# Altium schematic sheet NEAR.SchDoc
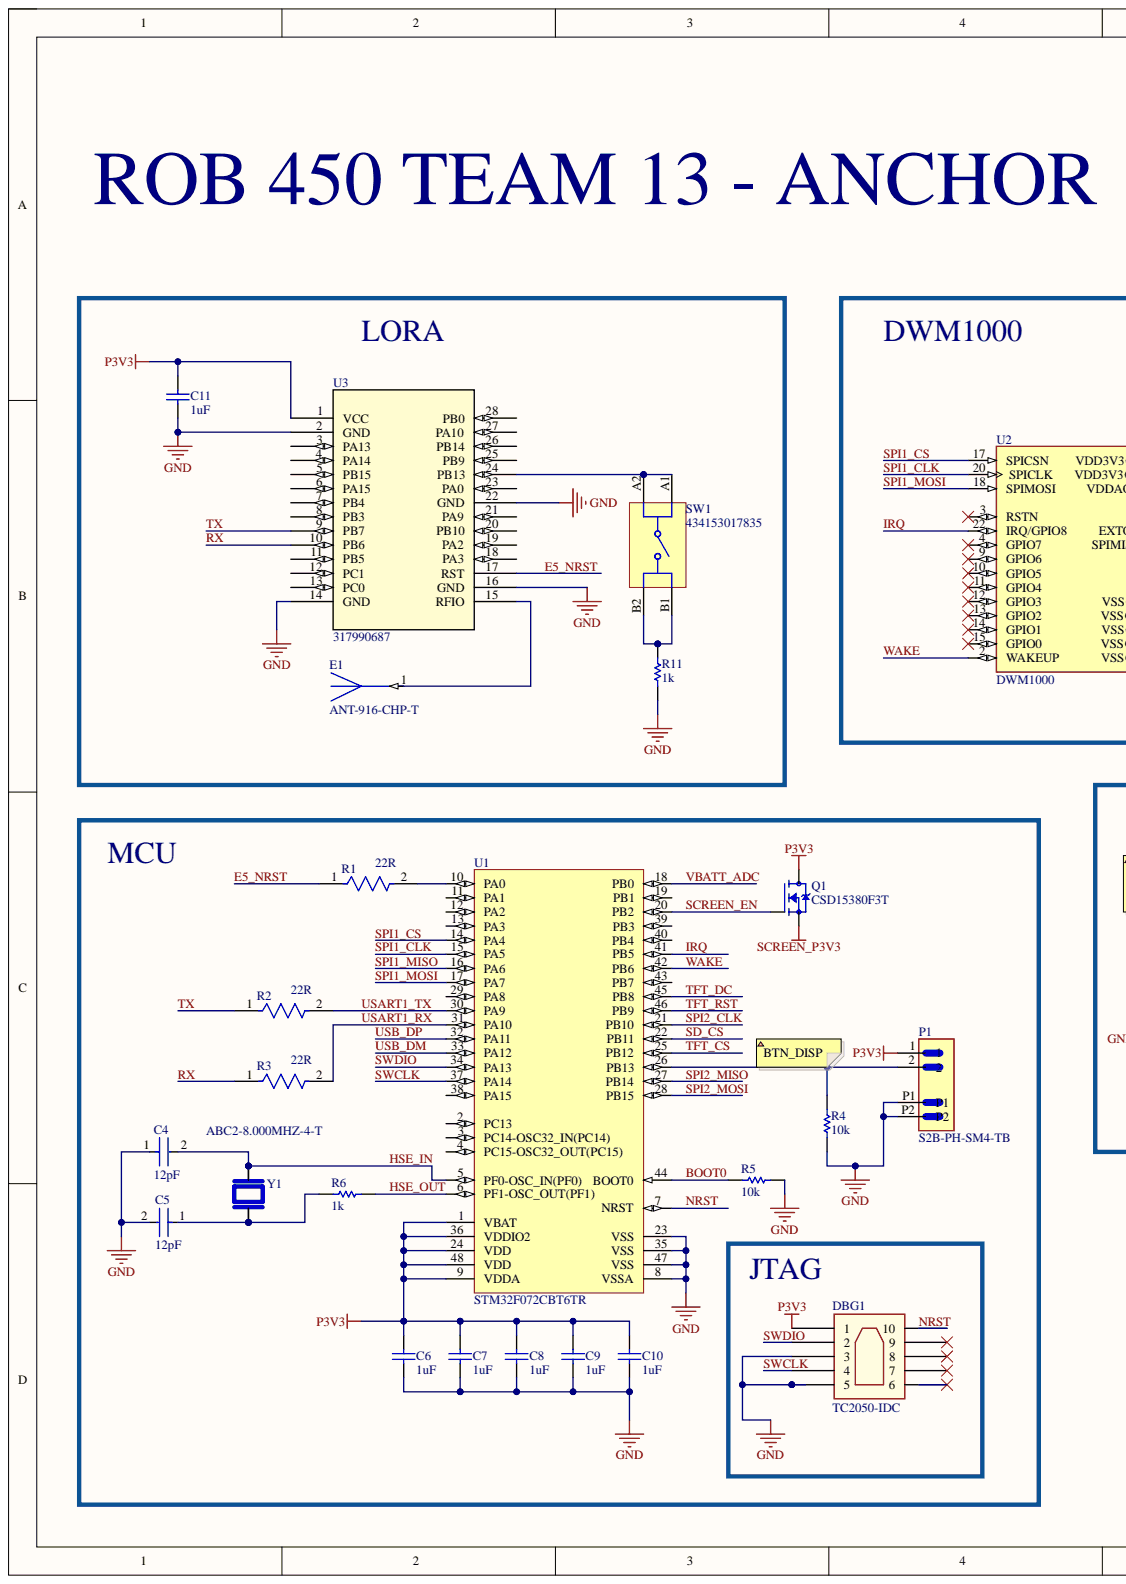
[diagram p0: NEAR.SchDoc, left]
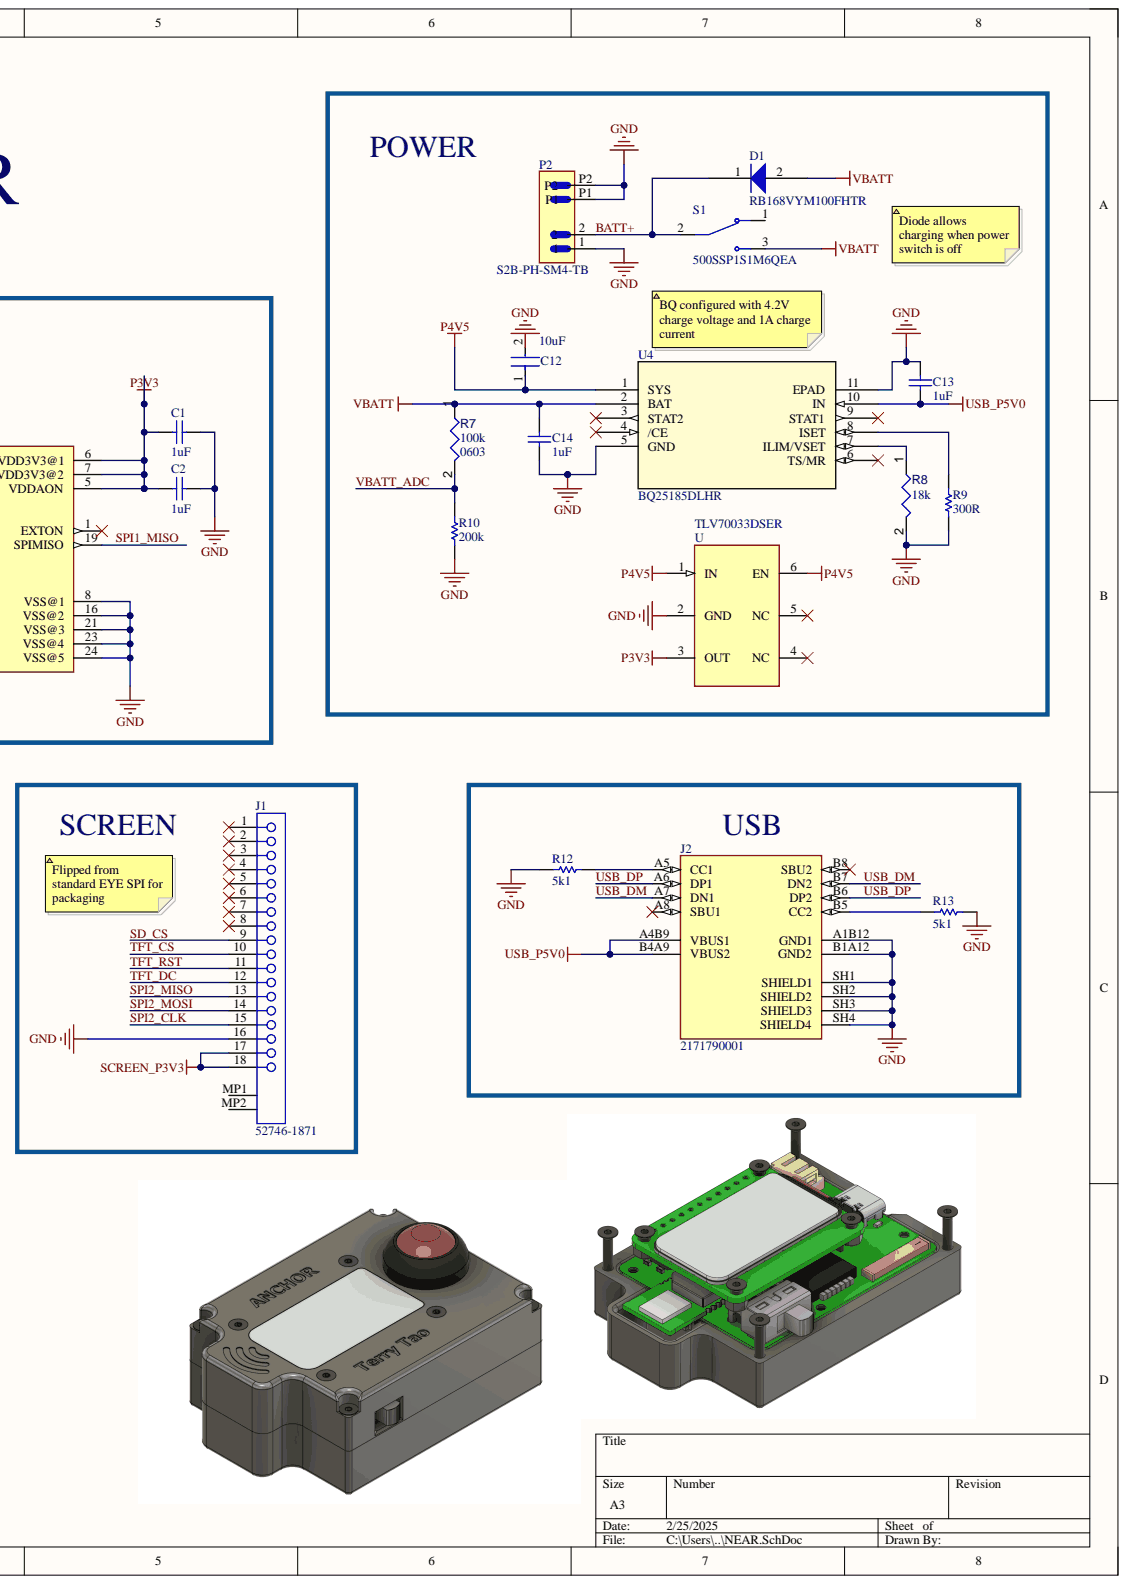
[diagram p1: NEAR.SchDoc, right]

## Parts
C1  1uF  [CAP_0603]
C2  1uF  [CAP_0603]
C4  12pF  [FP-C0603C-CF-MFG]
C5  12pF  [FP-C0603C-CF-MFG]
C6  1uF  [CAP_0603]
C7  1uF  [CAP_0603]
C8  1uF  [CAP_0603]
C9  1uF  [CAP_0603]
C10  1uF  [CAP_0603]
C11  1uF  [CAP_0603]
C12  10uF  [FP-GRM188-0_1-e0_2_0_55-IPC_A]
C13  1uF  [CAP_0603]
C14  1uF  [CAP_0603]
D1  RB168VYM100FHTR  [RB168VYM100FHTR-Footprint-1]
DBG1  TC2050-IDC  [TC2050-IDC-Footprint-2]
E1  ANT-916-CHP-T  [XDCR_ANT-916-CHP-T]
J1  52746-1871  [MOLX-52746-1871]
J2  2171790001  [MOLEX_2171790001]
P1  S2B-PH-SM4-TB  [JST_S2B-PH-SM4-TB]
P2  S2B-PH-SM4-TB  [JST_S2B-PH-SM4-TB]
Q1  CSD15380F3T  [TRXDFN69X60X35-3N]
R1  22R  [FP-CPF0603-MFG]
R2  22R  [FP-CPF0603-MFG]
R3  22R  [FP-CPF0603-MFG]
R4  10k  [RESC1609X55X30LL15T15]
R5  10k  [RESC1609X55X30LL15T15]
R6  1k  [RESC1608X55X30LL15T15]
R7  100k  Case/Package: 0603  [RESC1608X55X25ML10T15]
R8  value 18k  [CAPC1608X06N]  (hidden comment: RC0603JR-0718KL)
R9  300R  [RESC1608X55X30ML15T15]
R10  200k  [RESC1608X55X25LL10T15]
R11  1k  [RESC1608X55X30LL15T15]
R12  5k1  [RESC1608X55X25ML10T15]
R13  5k1  [RESC1608X55X25ML10T15]
S1  500SSP1S1M6QEA  [SW_500SSP1S1M6QEA]
SW1  434153017835  [434153017835]
U  TLV70033DSER  [SON50P150X150X80-6N]
U1  STM32F072CBT6TR  [STM-LQFP48_M]
U2  DWM1000  [XCVR_DWM1000]
U3  317990687  [QFN_90687_SEE]
U4  BQ25185DLHR  [WSON10_DLH_TEX]
Y1  ABC2-8.000MHZ-4-T  [XTAL_ABC2-8.000MHZ-4-T]

## Nets
GND: C1.2 C2.2 C4.1 C5.2 C6.1 C7.1 C8.1 C9.1 C10.1 C11.1 C12.2 C13.2 C14.1 DBG1.3 DBG1.5 J1.16 J2.A1B12(GND1) J2.B1A12(GND2) J2.SH1(SHIELD1) J2.SH2(SHIELD2) J2.SH3(SHIELD3) J2.SH4(SHIELD4) P1.P1 P1.P2 P2.1 P2.P1 P2.P2 R4.1 R5.1 R8.2 R9.2 R10.2 R11.2 R12.2 R13.1 U.2(GND) U1.8(VSSA) U1.23(VSS) U1.35(VSS) U1.47(VSS) U2.8(VSS@1) U2.16(VSS@2) U2.21(VSS@3) U2.23(VSS@4) U2.24(VSS@5) U3.2(GND) U3.14(GND) U3.16(GND) U3.22(GND) U4.5(GND) U4.11(EPAD)
P3V3: C1.1 C2.1 C6.2 C7.2 C8.2 C9.2 C10.2 C11.2 DBG1.1 P1.1 Q1.3 U.3(OUT) U1.1(VBAT) U1.9(VDDA) U1.24(VDD) U1.36(VDDIO2) U1.48(VDD) U2.5(VDDAON) U2.6(VDD3V3@1) U2.7(VDD3V3@2) U3.1(VCC)
P4V5: C12.1 U.1(IN) U.6(EN) U4.1(SYS)
SCREEN_P3V3: J1.17 J1.18 Q1.2
USB_P5V0: C13.1 J2.A4B9(VBUS1) J2.B4A9(VBUS2) U4.10(IN)
VBATT: C14.2 D1.2 R7.1 S1.3 U4.2(BAT)
BATT+: D1.1 P2.2 S1.2
BOOT0: R5.2 U1.44(BOOT0)
E5_NRST: R1.1 U3.17(RST)
HSE_IN: C4.2 U1.5(PF0-OSC_IN(PF0)) Y1.2 Y1.3
HSE_OUT: R6.1 U1.6(PF1-OSC_OUT(PF1))
IRQ: U1.41(PB5) U2.22(IRQ/GPIO8)
NRST: DBG1.10 U1.7(NRST)
RX: R3.1 U3.10(PB6)
SCREEN_EN: Q1.1 U1.20(PB2)
SD_CS: J1.9 U1.22(PB11)
SPI1_CLK: U1.15(PA5) U2.20(SPICLK)
SPI1_CS: U1.14(PA4) U2.17(SPICSN)
SPI1_MISO: U1.16(PA6) U2.19(SPIMISO)
SPI1_MOSI: U1.17(PA7) U2.18(SPIMOSI)
SPI2_CLK: J1.15 U1.21(PB10)
SPI2_MISO: J1.13 U1.27(PB14)
SPI2_MOSI: J1.14 U1.28(PB15)
SWCLK: DBG1.4 U1.37(PA14)
SWDIO: DBG1.2 U1.34(PA13)
TFT_CS: J1.10 U1.25(PB12)
TFT_DC: J1.12 U1.45(PB8)
TFT_RST: J1.11 U1.46(PB9)
TX: R2.1 U3.9(PB7)
USART1_RX: R3.2 U1.31(PA10)
USART1_TX: R2.2 U1.30(PA9)
USB_DM: J2.A7(DN1) J2.B7(DN2) U1.33(PA12)
USB_DP: J2.A6(DP1) J2.B6(DP2) U1.32(PA11)
VBATT_ADC: R7.2 R10.1 U1.18(PB0)
WAKE: U1.42(PB6) U2.2(WAKEUP)
NetC5_1: C5.1 R6.2 Y1.1 Y1.4
NetE1_1: E1.1 U3.15(RFIO)
NetJ2_A5: J2.A5(CC1) R12.1
NetJ2_B5: J2.B5(CC2) R13.2
NetP1_2: P1.2 R4.2 U1.26(PB13)
NetR1_2: R1.2 U1.10(PA0)
NetR8_1: R8.1 U4.7(ILIM/VSET)
NetR9_1: R9.1 U4.8(ISET)
NetR11_1: R11.1 SW1.B1 SW1.B2
NetSW1_A1: SW1.A1 SW1.A2 U3.24(PB13)

Not connected: DBG1 6 7 8 9; J1 1 2 3 4 5 6 7 8 MP1 MP2; J2 A8(SBU1) B8(SBU2); S1 1; U 4(NC) 5(NC); U1 2(PC13) 3(PC14-OSC32_IN(PC14)) 4(PC15-OSC32_OUT(PC15)) 11(PA1) 12(PA2) 13(PA3) 19(PB1) 29(PA8) 38(PA15) 39(PB3) 40(PB4) 43(PB7); U2 1(EXTON) 3(RSTN) 4(GPIO7) 9(GPIO6) 10(GPIO5) 11(GPIO4) 12(GPIO3) 13(GPIO2) 14(GPIO1) 15(GPIO0); U3 3(PA13) 4(PA14) 5(PB15) 6(PA15) 7(PB4) 8(PB3) 11(PB5) 12(PC1) 13(PC0) 18(PA3) 19(PA2) 20(PB10) 21(PA9) 23(PA0) 25(PB9) 26(PB14) 27(PA10) 28(PB0); U4 3(STAT2) 4(/CE) 6(TS/MR) 9(STAT1)

## Library data of the parts
C1 C2 C6-C11 C13 C14 = CMP-001-00097-1: Cap Ceramic 1uF 25V X7R 10% Pad SMD 0603 125C Automotive T/R; Capacitance: 1uF; Case/Package: 0603; Component Kind: Standard; Dielectric: X7R; Tolerance: 10%; Voltage Rating: 25V; Library Name: MRacing FSAE
C4 C5 = CMP-2006-03703-3: Ceramic Capacitor 12Pf 50V, C0G, 5%, 0603, Full Reel; Manufacturer: KEMET; Manufacturer Part Number: C0603C120J5GACTU; Automotive Grade: Grade 1; Temperature Coefficient: 30ppm/°C; Tolerance: 0.05; Automotive: Yes; Capacitance: 12 pF; Category: Cap; Component Kind: Standard; ComponentLink2Description: Datasheet; ComponentLink2URL: https://api.kemet.com/component-edge/download/datasheet/C0603C100J5GACAUTO.pdf; Device Class L1: Passive Components; Device Class L2: Capacitors; Device Class L3: Ceramic Capacitors; Digikey Description: Ceramic Capacitor 12Pf 50V, C0G, 5%, 0603, Full Reel; Height: 0.87mm; Lead Free: Yes; Library Name: Altium Content Vault; Material: Ceramic; Mouser Description: Ceramic Capacitor 12Pf 50V, C0G, 5%, 0603, Full Reel; Octopart: https://octopart.com/c0603c120j5gactu-kemet-108780; Package: C0603C-CF; RoHS: TRUE; Temperature: -55°C to +125°C; Temperature Characteristic: C0G; Temperature Range High: +125°C; Temperature Range Low: -55°C; Value: 12 pF; Voltage Rating: 50 V
C12 = CMP-2006-02792-2: Chip Multilayer Ceramic Capacitors for General Purpose, 0603, 10uF, X5R, 15%, 20%, 6.3V; Capacitance: 10uF; Case Code (Imperial): 0603; Case Code (Metric): 1608; Case/Package: 0603; Component Kind: Standard; Depth: 0.8mm; Dielectric: X5R; Height: 0.8mm; Lead Free: Lead Free; Length: 1.6mm; Library Name: Altium Content Vault; Material: Ceramic; Max Operating Temperature: 85°C; Min Operating Temperature: -55°C; Mount: Surface Mount; Package Quantity: 4000; Packaging: Tape and Reel; Radiation Hardening: No; REACH SVHC: No SVHC; Termination: SMD/SMT; Thickness: 0.035inch; Tolerance: 20%; Voltage: 6.3V; Voltage Rating: 6.3V; Voltage Rating (DC): 6.3V; Width: 0.031inch
D1 = CMP-004-00046-4: Schottky Barrier Diode; Component Kind: Standard; Design Item ID: RB168VYM100FHTR; Source: RB168VYM100FHTR.SchLib; Library Name: MRacing FSAE
DBG1 = CMP-002-00071-4: CBL PLUG-OF-NAILS 10-PIN W/LEGS; Component Kind: Standard; Library Name: MRacing FSAE
E1 = CMP-00025-00008-1: 915 MHz Monopole Ceramic Chip Antenna; MF: Linx Technologies; Availability: In Stock; Case/Package: None; Check_prices: https://www.snapeda.com/parts/ANT-916-CHP-T/Linx/view-part/168085/?ref=eda; Component Kind: Standard; Component Type: Standard; Design Item ID: ANT-916-CHP-T; MP: ANT-916-CHP-T; Pins: 1; Price: None; SnapEDA_Link: https://www.snapeda.com/parts/ANT-916-CHP-T/Linx/view-part/168085/?ref=snap; Source: ANT-916-CHP-T.SchLib; Library Name: MRacing FSAE
J1 = CMP-1241-00380-1: 0.5 mm Pitch Easy-On(TM) Type FPC Connector, 2.0 mm Mated Height, Right Angle, ZIF, SMT, Bottom Contact, 18 Circuits, -20 to 85 degC, ELV and RoHS Compliant, Tape and Reel; Manufacturer: Molex; Circuits (Loaded): 18; Current (Max per Contact): 0.5A; Durability (mating cycles max): 20; Entry Angle: 90degrees Angle; Mated Height: 2.00mm; Material - Metal: Phosphor Bronze; Material - Plating Mating: Gold; PCB Locator: No; PCB Retention: Yes; Pitch - Mating Interface: 0.50mm; Voltage (Max): 50V; Component Kind: Standard; ComponentLink1Description: Manufacturer URL; ComponentLink1URL: http://www.molex.com/molex/index.jsp; ComponentLink2Description: Datasheet; ComponentLink2URL: http://www.molex.com/webdocs/datasheets/pdf/en-us/0527461871_FFC_FPC_CONNECTORS.pdf; ComponentLink3Description: Package Specification; ComponentLink3URL: http://www.molex.com/pdm_docs/sd/527461871_sd.pdf; Contact Position: Bottom; Library Name: Altium Content Vault; Material - Plating Termination: Gold; Mounting Technology: Surface Mount; Number of Rows: 1; Orientation: Right Angle; PackageDescription: 18-Lead FPC Connector, Pitch 0.5 mm; PackageReference: 52746-1871; PackageVersion: Rev. H, 05/2009; Packing: Tape and Reel; PartNumber: 52746-1871; Polarized to PCB: Yes; RoHS: TRUE; Stackable: No
J2 = CMP-002-00656-1: USB Type C - Connector, Top-mount SMT, 16pos; MF: Molex; MP: 217179-0001; Case/Package: None; Check_prices: https://www.snapeda.com/parts/217179-0001/Molex/view-part/?ref=eda; Component Kind: Standard; Design Item ID: 2171790001; SnapEDA_Link: https://www.snapeda.com/parts/217179-0001/Molex/view-part/?ref=snap; Source: 2171790001.SchLib; Library Name: MRacing FSAE
P1 P2 = CMP-002-00662-3: Connector Header Surface Mount, Right Angle 2 position 0.079 (2.00mm); MF: JST Sales; Availability: In Stock; Case/Package: None; Check_prices: https://www.snapeda.com/parts/S2B-PH-SM4-TB/JST+Sales+America+Inc./view-part/?ref=eda; Component Kind: Standard; Component Type: Standard; Design Item ID: S2B-PH-SM4-TB; MP: S2B-PH-SM4-TB; Pins: 2; Price: None; Purchase-URL: https://pricing.snapeda.com/search/part/S2B-PH-SM4-TB/?ref=eda; SnapEDA_Link: https://www.snapeda.com/parts/S2B-PH-SM4-TB/JST+Sales+America+Inc./view-part/?ref=snap; Source: S2B-PH-SM4-TB.SchLib; Library Name: MRacing FSAE
Q1 = CMP-013-00048-1: 20-V N-Channel FemtoFET MOSFET 3-PICOSTAR -55 to 150; Availability: Unavailable; Case/Package: None; Component Kind: Standard; Component Type: Standard; Design Item ID: CSD15380F3T; MP: CSD15380F3T; Pins: 3; Price: None; Source: CSD15380F3T.SchLib; Library Name: MRacing FSAE
R1-R3 = CMP-03211-007811-1: RES SMD 22Ω 0.1% 1/16W 0603; Manufacturer: TE Connectivity; Manufacturer Part Number: CPF0603B22RE1; Power Rating: 0.063W; Temperature Coefficient: 25ppm/°C; Voltage Rating: 50V; Automotive: No; Category: Res; Component Kind: Standard; ComponentLink2Description: Datasheet; ComponentLink2URL: https://www.te.com/commerce/DocumentDelivery/DDEController?Action=showdoc&DocId=Data+Sheet%7F1773200%7FM%7Fpdf%7FEnglish%7FENG_DS_1773200_M.pdf%7F3-1676481-2; Composition: Thin Film; Device Class L1: Passive Components; Device Class L2: Resistors; Device Class L3: Chip SMD Resistors; Height: 0.55mm; Ipc Land Pattern Name: RESC15580X45; Lead Free: Yes; Library Name: Altium Content Vault; Octopart: https://octopart.com/cpf0603b22re1-te+connectivity-9539398; octopart_url: https://octopart.com/cpf0603b22re1-te+connectivity-9539398; Package: CPF0603; packaging: 1k Reel; Resistance: 22Ω; RoHS: TRUE; Series: CPF; Temperature: -55°C to +155°C; Temperature Range High: +155°C; Temperature Range Low: -55°C; Tolerance: 0.1%; Value: 22Ω
R4 R5 = CMP-1012-00623-3: Chip Resistor, 10 KOhm, +/- 1%, 0.1 W, -55 to 155 degC, 0603 (1608 Metric), RoHS, Tape and Reel; Manufacturer: Panasonic; Case\/Package: 0603; Max Power Dissipation: 100mW; Resistance: 10KOhm; Temperature Coefficient: 100ppm\/degreesC; Voltage Rating: 75V; Case Code (Imperial): 0603; Case Code (Metric): 1608; Component Kind: Standard; Composition: ThickFilm; Contact Plating: Tin; Datasheet URL: https://industrial.panasonic.com/cdbs/www-data/pdf/RDA0000/AOA0000C304.pdf; Datasheet Version: 02/2017; Depth: 0.8mm; Height: 0.45mm; Lead Free: LeadFree; Length: 1.6mm; Library Name: Altium Content Vault; Manufacturer URL: http://www.panasonic.com/; Max Operating Temperature: 155degC; Military Standard: Not; Min Operating Temperature: -55degC; Mount: SurfaceMount; Mounting Technology: SurfaceMount; Number of Pins: 2; Number of Terminations: 2; Package Description: 2-Pin Surface Mount Device, Body 1.6 x 0.85 mm; Package Quantity: 1; Package Reference: 603; Packaging: TapeandReel; Power Rating: 100mW; Radiation Hardening: No; REACH SVHC: NoSVHC; RoHSCompliant: true; Termination: SMD/SMT; Tolerance: 1%; Voltage Rating (DC): 75V; Width: 0.031inch
R6 R11 = CMP-2000-00180-1: Manufacturer: Panasonic; Part Number: ERJ-3EKF1001V; Component Kind: Standard; Library Name: Altium Content Vault
R7 = CMP-009-00023-4: Chip Resistor, 100 KOhm, +/- 1%, 0.1 W, -55 to 155 degC, 0603 (1608 Metric); Power: 100mW; Voltage Rating: 50V; Component Kind: Standard; Max Operating Temperature: 155°C; Min Operating Temperature: -55°C; Mounting Technology: SM; Pins: 2; RoHS Compliant: True; Tolerance: 1%; Value: 100k; Library Name: MRacing FSAE
R8 = CMP-009-00237-1: SMD Chip Resistor, 18 kOhm, ï¿½ 5%, 100 mW, 0603 [1608 Metric], Thick Film, General Purpose; Max Power Dissipation: 100mW; Temperature Coefficient: 100ppm/°C; Voltage Rating: 75V; Voltage Rating (DC): 50V; Case Code (Imperial): 0603; Case Code (Metric): 1608; Case/Package: 0603; Component Kind: Standard; Features: Moisture Resistant; Height: 0.022inch; Lead Free: Lead Free; Length: 0.063inch; Max Operating Temperature: 155°C; Min Operating Temperature: -55°C; Number of Terminations: 2; Package Quantity: 1; Packaging: Tape and Reel; Pins: 2; Power Rating: 100mW; Reach SVHC Compliant: No; Resistance Tolerance: 5%; Resistor Type: Thick Film; RoHS Compliant: Yes; Series: RC; Termination: SMD/SMT; Tolerance: 5%; Weight: 7.1E-05oz; Width: 0.8mm; Library Name: MRacing FSAE
R9 = CMP-2000-00766-1: ERJ-3GEYJ301V; Manufacturer: Panasonic; Component Kind: Standard; Library Name: Altium Content Vault; Part Number: ERJ-3GEYJ301V
R10 = CMP-2000-04252-1: Manufacturer: Yageo; Part Number: RC0603JR-07200KL; Component Kind: Standard; Library Name: Altium Content Vault
R12 R13 = CMP-2000-04091-1: Manufacturer: Yageo; Part Number: RC0603FR-075K1L; Component Kind: Standard; Library Name: Altium Content Vault
S1 = CMP-011-00014-1: Slide Switch SPDT Through Hole, Right Angle; MF: E-Switch; Case/Package: None; Check_prices: https://www.snapeda.com/parts/500SSP1S1M6QEA/E-Switch/view-part/?ref=eda; Component Kind: Standard; Component Type: Standard; Design Item ID: 500SSP1S1M6QEA; Digi-Key_Part_Number: EG2478-ND; MP: 500SSP1S1M6QEA; Pins: 3; Purchase-URL: https://pricing.snapeda.com/search/part/500SSP1S1M6QEA/?ref=eda; SnapEDA_Link: https://www.snapeda.com/parts/500SSP1S1M6QEA/E-Switch/view-part/?ref=snap; Source: 500SSP1S1M6QEA.SchLib; Library Name: MRacing FSAE
SW1 = CMP-208025-000001-3: Tactile Switch, 0.05A, 12Vdc, 350Gf, Smd Rohs Compliant: Yes; Actuator Material: Liquid Crystal Polymer, Polymer; Contact Current Rating: 50mA; Contact Resistance: 100mΩ; Illumination Type: Non-Illuminated; Life (Cycles): 200kcycles; Switch Function: Off-Mom; Switch Travel: 150µm; Throw Configuration: SPST; Voltage Rating: 12V; Component Kind: Standard; Contact Plating: Silver; Current Rating: 50mA; Height: 1.7mm; Insulation Resistance: 100MΩ; Library Name: Altium Content Vault; Max Operating Temperature: 85°C; Mechanical Life: 200kcycles; Min Operating Temperature: -40°C; Mount: Surface Mount; Packaging: Tape and Reel; RoHS: Compliant; Voltage Rating (DC): 12V
U = CMP-019-00083-5: Linear Voltage Regulator IC 1 Output 200mA 6-WSON _1.5x1.5_; MF: Texas Instruments; Availability: Unavailable; Case/Package: WFDFN-6 Texas Instruments; Component Kind: Standard; Component Type: Standard; Design Item ID: TLV70033DSER; MP: TLV70033DSER; Pins: 4; Price: None; SnapEDA_Link: https://www.snapeda.com/parts/TLV70033DSER/Texas%20Instruments/view-part/562119/?ref=snap; Source: SnapEDAsch.SchLib; Library Name: MRacing FSAE
U1 = CMP-0304-00171-1: ARM Cortex-M0 32-bit MCU, 128 KB Flash, 16 KB Internal RAM, 37 I/Os, 48-Pin LQFP, -40 to 85 degC, Tape and Reel; D/A Converter: 1; FLASH Size (Prog) (kB): 128; I/Os (High Current): 37; Internal RAM Size (kB): 16; Operating Frequency(F) (Processor speed) (MHz): 48; Supply Voltage(Vcc) min (V): 2; Component Kind: Standard; ComponentLink1Description: Manufacturer URL; ComponentLink1URL: http://www.st.com/; ComponentLink2Description: Datasheet; ComponentLink2URL: http://www.st.com/st-web-ui/static/active/en/resource/technical/document/datasheet/DM00090510.pdf; Core: ARM Cortex-M0; DatasheetVersion: Rev. 5; Library Name: Altium Content Vault; Mounting Technology: Surface Mount; Package Description: 48-Pin Low Profile Quad Flat Package, Body 7 x 7 mm, Pitch 0.5 mm; Package Reference: LQFP48; Packing: Tape and Reel
U2 = CMP-236-000000-2: 802.15.4 IR-UWB Transceiver Module 3.5GHz ~ 6.5GHz Integrated, Chip Surface Mount; MF: Decawave Limited; Availability: Unavailable; Component Kind: Standard; Component Type: Standard; Design Item ID: DWM1000; MP: DWM1000; Package: Module Decawave; Pin Count: 24; Price: None; SnapEDA_Link: https://www.snapeda.com/parts/DWM1000/Decawave%20Limited/view-part/327593/?ref=snap; Source: SnapEDAsch.SchLib; Library Name: MRacing FSAE
U3 = CMP-031-00023-1: No Description Available; Mfr_Name: BeagleBoard; Component Kind: Standard; Design Item ID: 317990687; RefDes: RefDes; Source: 317990687.SchLib; Type: Type; Library Name: MRacing FSAE
U4 = CMP-019-00082-1: No Description Available; Mfr_Name: Texas Instruments; Component Kind: Standard; Design Item ID: BQ25185DLHR; RefDes: RefDes; Source: BQ25185DLHR.SchLib; Type: Power Management; Library Name: MRacing FSAE
Y1 = CMP-003-00037-3: LOW FREQUENCY CERAMIC SURFACE MOUNT MICROPROCESSOR CRYSTAL; MF: Abracon; Availability: In Stock; Case/Package: SMD-4 Abracon; Check_prices: https://www.snapeda.com/parts/ABC2-8.000MHZ-4-T/Abracon/view-part/?ref=eda; Component Kind: Standard; Component Type: Standard; Design Item ID: ABC2-8.000MHZ-4-T; MP: ABC2-8.000MHZ-4-T; Pins: 4; Price: None; Purchase-URL: https://pricing.snapeda.com/search/part/ABC2-8.000MHZ-4-T/?ref=eda; SnapEDA_Link: https://www.snapeda.com/parts/ABC2-8.000MHZ-4-T/Abracon/view-part/?ref=snap; Source: ABC2-8.000MHZ-4-T.SchLib; Library Name: MRacing FSAE
